# Altium schematic sheet Center Console - Raspberry Pi Interface.SchDoc("Center Console - Raspberry Pi Interface")
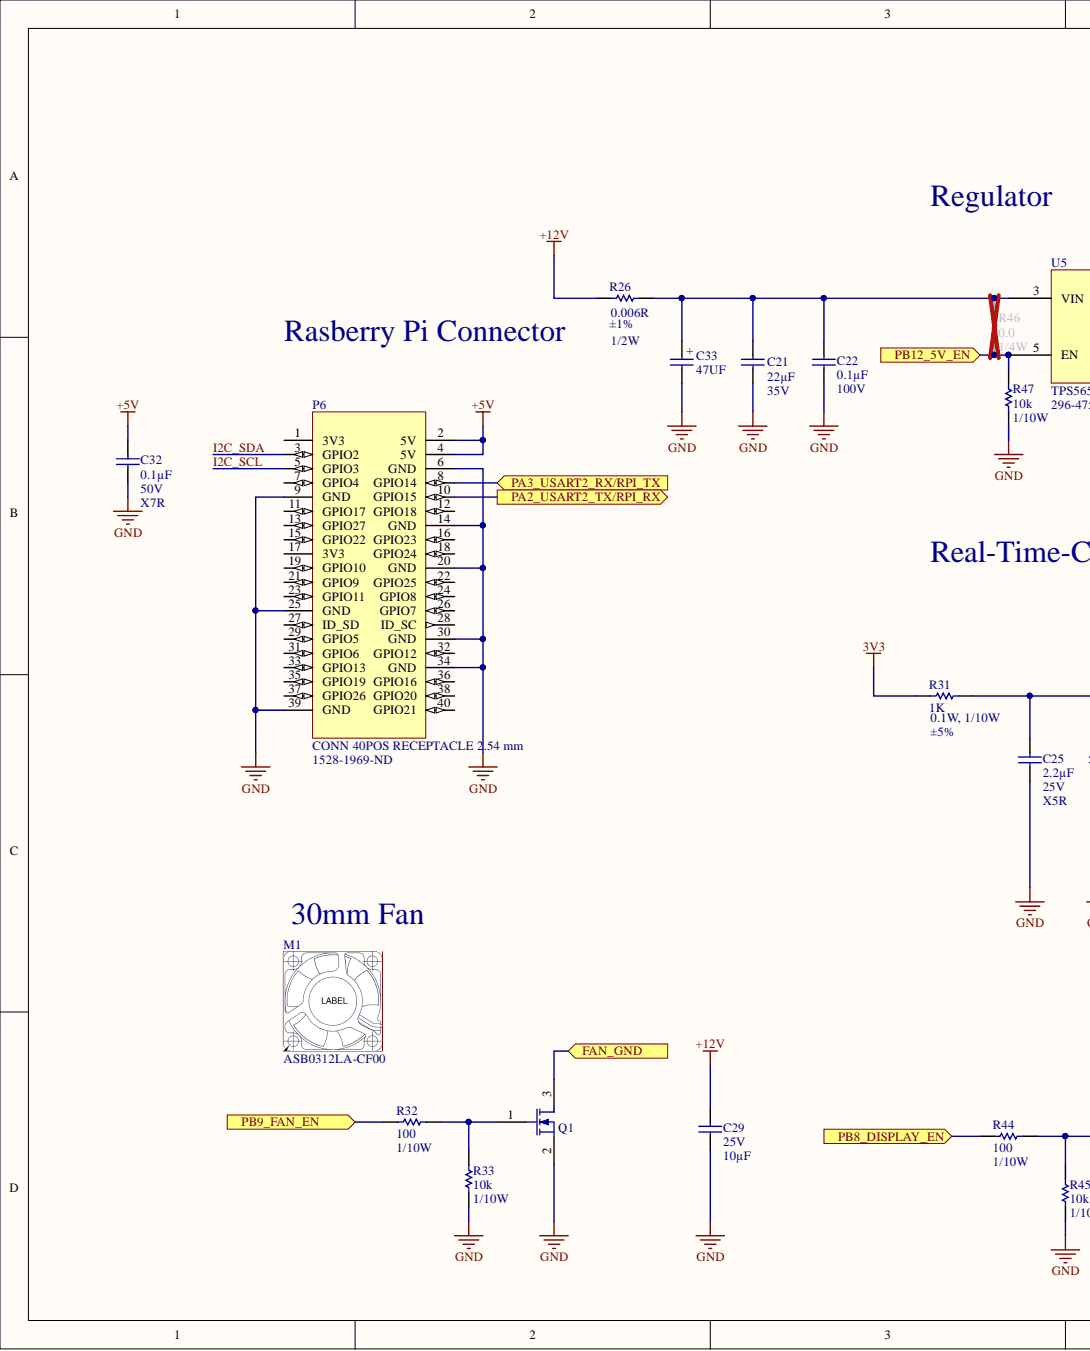
[diagram p0: Center Console - Raspberry Pi Interface.SchDoc, left]
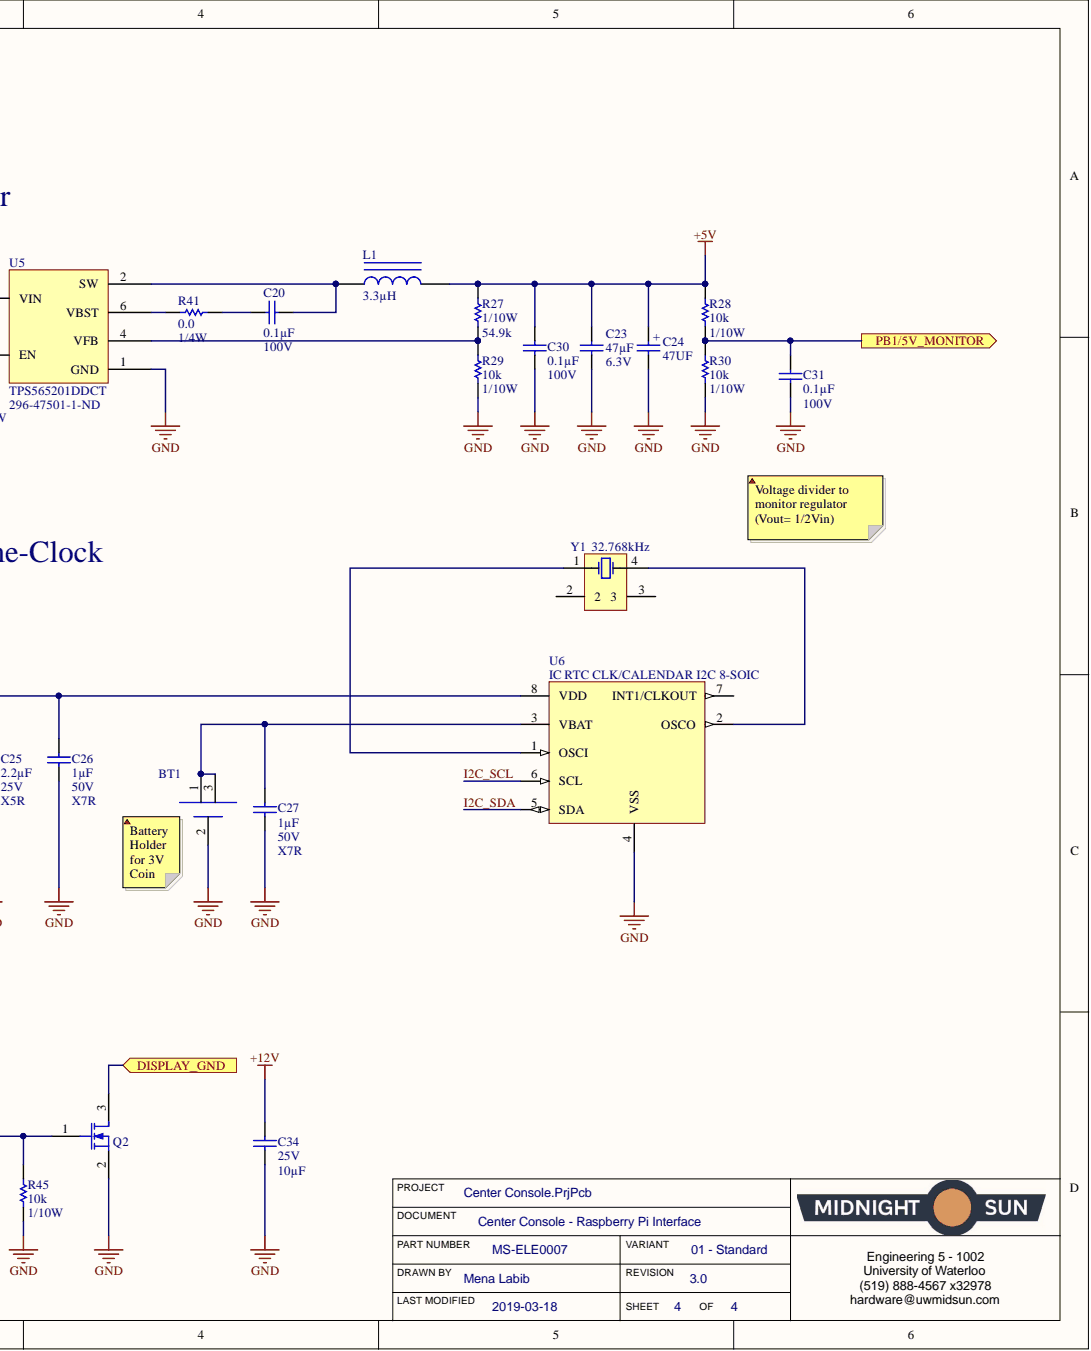
[diagram p1: Center Console - Raspberry Pi Interface.SchDoc, right]

## Parts
BT1  HOLDER BATTERY COIN 12MM DIA  [HOLDER COIN CELL 1220 3V]  (value not drawn)
C20  Voltage: 100V  Capacitance: 0.1µF  [CAP, 0805]  (hidden comment: CAP CER 0.1UF 100V 10% X7R 0805)
C21  Voltage Rating (DC): 35V  [CAP, 0805]  (hidden comment: CAP CER 22UF 35V X5R 0805)
C22  Voltage: 100V  Capacitance: 0.1µF  [CAP, 0805]  (hidden comment: CAP CER 0.1UF 100V 10% X7R 0805)
C23  Voltage Rating (DC): 6.3V  [1210]  (hidden comment: CAP CER 47UF 6.3V X7R 1210)
C24  47UF  [CAP ALUM 6.3MM RADIAL SMD]
C25  Voltage: 25V  Temperature Coefficient: X5R  Capacitance: 2.2µF  [CAP, 0603]  (hidden comment: CAP CER 2.2UF 25V 10% X5R 0603)
C26  Voltage: 50V  Temperature Coefficient: X7R  Capacitance: 1µF  [CAP, 0603]  (hidden comment: CAP CER 1UF 50V 10% X7R 0603)
C27  Voltage: 50V  Temperature Coefficient: X7R  Capacitance: 1µF  [CAP, 0603]  (hidden comment: CAP CER 1UF 50V 10% X7R 0603)
C29  Voltage - Rated: 25V  Capacitance: 10µF  [CAP, 0805]  (hidden comment: CAP CER 10uF 25V 10% X5R 0805)
C30  Voltage: 100V  Capacitance: 0.1µF  [CAP, 0805]  (hidden comment: CAP CER 0.1UF 100V 10% X7R 0805)
C31  Voltage: 100V  Capacitance: 0.1µF  [CAP, 0805]  (hidden comment: CAP CER 0.1UF 100V 10% X7R 0805)
C32  Voltage: 50V  Temperature Coefficient: X7R  Capacitance: 0.1µF  [CAP, 0603]  (hidden comment: CAP CER 0.1UF 50V 10% X7R 0603)
C33  47UF  [CAP ALUM 6.3MM RADIAL SMD]
C34  Voltage - Rated: 25V  Capacitance: 10µF  [CAP, 0805]  (hidden comment: CAP CER 10uF 25V 10% X5R 0805)
L1  Inductance: 3.3µH  [IND 3.3UH 5.2A 20 MOHM SMD VLP8040]  (hidden comment: IND 3.3uH 5.2A 20MOHM SMD)
M1  Manufacturer Part Number: ASB0312LA-CF00  [FAN AXIAL 30x10MM 12VDC]  (hidden comment: FAN AXIAL 30x10MM 12VDC)
P6  CONN 40POS RECEPTACLE 2.54 mm  Supplier Part Number 1: 1528-1969-ND  [CONN 40POS RECEPTACLE 2.54 MM]
Q1  MOSFET N-CH 30V 6.2A 0.9W SOT-23  [MOSFET N-CH 30V 6.2A 0.9W SOT-23]  (value not drawn)
Q2  MOSFET N-CH 30V 6.2A 0.9W SOT-23  [MOSFET N-CH 30V 6.2A 0.9W SOT-23]  (value not drawn)
R26  Tolerance: ±1%  Resistance (Ohms): 0.006R  [RES 1206]  (hidden comment: RES 0.006 OHM 1% 1/2W 1206)
R27  Resistance (Ohms): 54.9k  Power (Watts): 1/10W  [RES, 0603]  (hidden comment: RES 54.9K OHM 1% 1/10W 0603)
R28  Resistance: 10k  Power: 1/10W  [RES, 0603]  (hidden comment: RES 10K OHM 1% 1/10W 0603)
R29  Resistance: 10k  Power: 1/10W  [RES, 0603]  (hidden comment: RES 10K OHM 1% 1/10W 0603)
R30  Resistance: 10k  Power: 1/10W  [RES, 0603]  (hidden comment: RES 10K OHM 1% 1/10W 0603)
R31  1K  Tolerance: ±5%  Power (Watts): 0.1W, 1/10W  [RES, 0603]
R32  Power: 1/10W  [RES, 0603]  (hidden comment: RES 100 OHM 1% 1/10W 0603)
R33  Resistance: 10k  Power: 1/10W  [RES, 0603]  (hidden comment: RES 10K OHM 1% 1/10W 0603)
R41  Resistance: 0.0  Power (Watts): 1/4W  [RES, 0603]  (hidden comment: RES 0.0 OHM 1/4W 0603)
R44  Power: 1/10W  [RES, 0603]  (hidden comment: RES 100 OHM 1% 1/10W 0603)
R45  Resistance: 10k  Power: 1/10W  [RES, 0603]  (hidden comment: RES 10K OHM 1% 1/10W 0603)
R46  Resistance: 0.0  Power (Watts): 1/4W  [RES, 0603]  (hidden comment: RES 0.0 OHM 1/4W 0603)
R47  Resistance: 10k  Power: 1/10W  [RES, 0603]  (hidden comment: RES 10K OHM 1% 1/10W 0603)
U5  Supplier Part Number 1: 296-47501-1-ND  Manufacturer Part Number: TPS565201DDCT  [IC REG BUCK 4.5V TO 17V, 5A]  (hidden comment: IC REG BUCK 4.5V TO 17V, 5A, SYNCHRONOUS STE)
U6  IC RTC CLK/CALENDAR I2C 8-SOIC  Interface: I2C  [SOIC8]
Y1  Frequency: 32.768kHz  [4-SOJ]  (hidden comment: CRYSTAL 32.7680KHZ 12.5PF SMD)

## Ports
DISPLAY_GND, FAN_GND, PA2_USART2_TX/RPI_RX, PA3_USART2_RX/RPI_TX, PB1/5V_MONITOR, PB8_DISPLAY_EN, PB9_FAN_EN, PB12_5V_EN

## Nets
3V3: R31.1
+5V: C23.1 C24.1 C30.1 C32.1 L1.2 P6.2(5V) P6.4(5V) R27.1 R28.1
+12V: C29.1 C34.1 R26.1
GND: BT1.2 C21.2 C22.2 C23.2 C24.2 C25.2 C26.2 C27.2 C29.2 C30.2 C31.2 C32.2 C33.2 C34.2 P6.6(GND) P6.9(GND) P6.14(GND) P6.20(GND) P6.25(GND) P6.30(GND) P6.34(GND) P6.39(GND) Q1.2 Q2.2 R29.2 R30.2 R33.2 R45.2 R47.2 U5.1(GND) U6.4(VSS)
DISPLAY_GND: Q2.3 ; port DISPLAY_GND
FAN_GND: Q1.3 ; port FAN_GND
I2C_SCL_4: P6.5(GPIO3) U6.6(SCL)  [also labelled I2C_SCL]
I2C_SDA_4: P6.3(GPIO2) U6.5(SDA)  [also labelled I2C_SDA]
PA2_USART2_TX/RPI_RX: P6.10(GPIO15) ; port PA2_USART2_TX/RPI_RX
PA3_USART2_RX/RPI_TX: P6.8(GPIO14) ; port PA3_USART2_RX/RPI_TX
PB1/5V_MONITOR: C31.1 R28.2 R30.1 ; port PB1/5V_MONITOR
PB8_DISPLAY_EN: R44.1 ; port PB8_DISPLAY_EN
PB9_FAN_EN: R32.1 ; port PB9_FAN_EN
PB12_5V_EN: R46.2 R47.1 U5.5(EN) ; port PB12_5V_EN
NetBT1_1: BT1.1 BT1.3 C27.1 U6.3(VBAT)
NetC20_1: C20.1 R41.2
NetC20_2: C20.2 L1.1 U5.2(SW)
NetC21_1: C21.1 C22.1 C33.1 R26.2 R46.1 U5.3(VIN)
NetC25_1: C25.1 C26.1 R31.2 U6.8(VDD)
NetP6_1: P6.1(3V3)
NetP6_7: P6.7(GPIO4)
NetP6_11: P6.11(GPIO17)
NetP6_12: P6.12(GPIO18)
NetP6_13: P6.13(GPIO27)
NetP6_15: P6.15(GPIO22)
NetP6_16: P6.16(GPIO23)
NetP6_17: P6.17(3V3)
NetP6_18: P6.18(GPIO24)
NetP6_19: P6.19(GPIO10)
NetP6_21: P6.21(GPIO9)
NetP6_22: P6.22(GPIO25)
NetP6_23: P6.23(GPIO11)
NetP6_24: P6.24(GPIO8)
NetP6_26: P6.26(GPIO7)
NetP6_27: P6.27(ID_SD)
NetP6_28: P6.28(ID_SC)
NetP6_29: P6.29(GPIO5)
NetP6_31: P6.31(GPIO6)
NetP6_32: P6.32(GPIO12)
NetP6_33: P6.33(GPIO13)
NetP6_35: P6.35(GPIO19)
NetP6_36: P6.36(GPIO16)
NetP6_37: P6.37(GPIO26)
NetP6_38: P6.38(GPIO20)
NetP6_40: P6.40(GPIO21)
NetQ1_1: Q1.1 R32.2 R33.1
NetQ2_1: Q2.1 R44.2 R45.1
NetR27_2: R27.2 R29.1 U5.4(VFB)
NetR41_1: R41.1 U5.6(VBST)
NetU6_1: U6.1(OSCI) Y1.1
NetU6_2: U6.2(OSCO) Y1.4
NetU6_7: U6.7(INT1/CLKOUT)
NetY1_2: Y1.2
NetY1_3: Y1.3

## Library data of the parts
BT1 = HOLDER BATTERY COIN 12MM DIA: Coin cell holder smd; Manufacturer Part Number: 3000; Manufacturer: Keystone Electronics; Number of Cells: 1; Body Material: Bronze; Termination: SMD/SMT; Supplier Part Number 1: 36-3000-ND; Supplier 1: Digi-Key; Stock 1: 17093; REACH SVHC: No SVHC; Pricing 1: 1=0.58, 10=0.545, 50=0.4356, 100=0.4175, 250=0.38116, 500=0.363, 1000=0.30495, 2500=0.27225, 5000=0.26318 (USD); Packaging: Bulk; Package Quantity: 100; Number of Batteries: 1; Mount: Surface Mount,PCB; Material: Bronze; Lead Free: Lead Free; Height: 3.17mm; Diameter: 12mm; Contact Plating: Nickel; Contact Material: Bronze,Nickel; ComponentLink4URL: https://datasheet.ciiva.com/1391/1718262-1391630.pdf; ComponentLink4Description: https://datasheet.ciiva.com/1391/1718262-1391630.pdf; ComponentLink3URL: https://datasheet.ciiva.com/20221/18-3570e-20221596.pdf; ComponentLink3Description: https://datasheet.ciiva.com/20221/18-3570e-20221596.pdf; ComponentLink2URL: https://datasheet.ciiva.com/11714/0900766b8002ef78-11714721.pdf; ComponentLink2Description: https://datasheet.ciiva.com/11714/0900766b8002ef78-11714721.pdf; ComponentLink1URL: https://datasheet.ciiva.com/3/3000-3953.pdf; ComponentLink1Description: https://datasheet.ciiva.com/3/3000-3953.pdf; Component Kind: Standard; Library Name: Schematic Diagrams.SchLib
C20 C22 C30 C31 = CAP CER 0.1UF 100V 10% X7R 0805: 0.1µF ±10% 100V Ceramic Capacitor X7R 0805 (2012 Metric); Manufacturer Part Number 1: GCM21BR72A104KA37L; Manufacturer 1: Murata Electronics North America; Tolerance: ±10%; Thickness (Max): 0.055" (1.40mm); Temperature Coefficient: X7R; Supplier Part Number 1: 490-4789-1-ND; Supplier 1: Digi-Key; Size / Dimension: 0.079" L x 0.049" W (2.00mm x 1.25mm); Ratings: AEC-Q200; Part Status: Active; Packaging: Cut Tape (CT); Package / Case: 0805 (2012 Metric); Operating Temperature: -55°C ~ 125°C; Mounting Type: Surface Mount, MLCC; Lead Style: -; Lead Spacing: -; Height - Seated (Max): -; ComponentLink1URL: http://www.murata.com/~/media/webrenewal/support/library/catalog/products/capacitor/mlcc/c03e.ashx; ComponentLink1Description: http://www.murata.com/~/media/webrenewal/support/library/catalog/products/capacitor/mlcc/c03e.ashx; Component Kind: Standard; Library Name: Schematic Diagrams.SchLib
C21 = CAP CER 22UF 35V X5R 0805: 22µF ±20% 35V Ceramic Capacitor X5R 0805 (2012 Metric); Manufacturer Part Number: C2012X5R1V226M125AC; Manufacturer: TDK; Width: 0.049inch; Weight: 0.000194oz; Voltage Rating: 35V; Tolerance: 20%; Thickness: 0.057inch; Termination: SMD/SMT; Supplier Part Number 1: 445-14428-1-ND; Supplier 1: Digi-Key; Stock 1: 30; RoHS: Yes; REACH SVHC: No SVHC; Pricing 1: 1 = 1.29000, 10 = 0.98100, 100 = 0.70190, 500 = 0.54338, 1000 = 0.46791 (USD); Packaging: Tape and Reel; Package Quantity: 2000; Mount: Surface Mount; Min Operating Temperature: -55°C; Max Operating Temperature: 85°C; Length: 0.079inch; Lead Free: Lead Free; Height: 1.25mm; Dielectric Material: Ceramic; Dielectric: X5R; ComponentLink1URL: https://product.tdk.com/info/en/catalog/spec/mlccspec_commercial_general_midvoltage_en.pdf; ComponentLink1Description: https://product.tdk.com/info/en/catalog/spec/mlccspec_commercial_general_midvoltage_en.pdf; Component Kind: Standard; Case Code (Metric): 2012; Case Code (Imperial): 0805; Case/Package: 0805; Capacitance: 22uF; Library Name: Schematic Diagrams.SchLib
C23 = CAP CER 47UF 6.3V X7R 1210: 47µF ±10% 6.3V Ceramic Capacitor X7R 1210 (3225 Metric); Manufacturer Part Number: GCJ32ER70J476KE01L; Manufacturer: Murata; Width: 0.098inch; Weight: 0.00388oz; Voltage Rating: 6.3V; Tolerance: 10%; Thickness: 0.11inch; Termination: SMD/SMT; Supplier Part Number 1: 490-10559-1-ND; Supplier 1: Digi-Key; Stock 1: 191399; RoHS: Yes; Ratings: AEC-Q200; Pricing 1: 1 = 1.53000, 10 = 1.21200, 100 = 0.90890, 500 = 0.68670 (USD); Packaging: Tape and Reel; Package Quantity: 1000; Mount: Surface Mount; Min Operating Temperature: -55°C; Max Operating Temperature: 125°C; Length: 0.126inch; Lead Free: Lead Free; Height: 2.5mm; Features: Soft Termination; Dielectric: X7R; Component Kind: Standard; Case Code (Metric): 3225; Case Code (Imperial): 1210; Case/Package: 1210; Capacitance: 47uF; Library Name: Schematic Diagrams.SchLib
C24 C33 = CAP ALUM 47UF 20% 35V SMD: 47µF 35V Aluminum Electrolytic Capacitors Radial, Can - SMD 1000 Hrs @ 85°C; Manufacturer Part Number: EEE-1VA470WP; Manufacturer: Panasonic; Tolerance: 20%; Ripple Current (AC): 70mA; Life (Hours): 1000Hour; Voltage Rating (DC): 35V; Voltage Rating: 35V; Termination: SMD/SMT; Supplier Part Number 1: PCE3961CT-ND; Supplier 1: Digi-Key; Stock 1: 2820; Ripple Current: 70mA; REACH SVHC: Unknown; Radiation Hardening: No; Pricing 1: 1=0.38, 10=0.263, 100=0.1579, 500=0.11846 (USD); Packaging: Tape and Reel; Package Quantity: 1; Mount: Surface Mount,PCB; Min Operating Temperature: -40°C; Max Operating Temperature: 85°C; Length: 5.5mm; Lead Free: Lead Free; Height - Seated (Max): 0.213inch; Height: 5.4mm; Diameter: 6.3mm; Contact Plating: Tin; ComponentLink6URL: https://datasheet.ciiva.com/30206/11aba0000ce2-30206253.pdf; ComponentLink6Description: https://datasheet.ciiva.com/30206/11aba0000ce2-30206253.pdf; ComponentLink5URL: https://datasheet.ciiva.com/16507/aba0000ce2-16507965.pdf; ComponentLink5Description: https://datasheet.ciiva.com/16507/aba0000ce2-16507965.pdf; ComponentLink4URL: https://datasheet.ciiva.com/15165/getdatasheetpartid-86239-15165868.pdf; ComponentLink4Description: https://datasheet.ciiva.com/15165/getdatasheetpartid-86239-15165868.pdf; ComponentLink3URL: https://datasheet.ciiva.com/14598/70255710-14598937.pdf; ComponentLink3Description: https://datasheet.ciiva.com/14598/70255710-14598937.pdf; ComponentLink2URL: https://datasheet.ciiva.com/2983/aba0000ce2-2983927.pdf; ComponentLink2Description: https://datasheet.ciiva.com/2983/aba0000ce2-2983927.pdf; ComponentLink1URL: https://datasheet.ciiva.com/2983/aba0000ce2-2983926.pdf; ComponentLink1Description: https://datasheet.ciiva.com/2983/aba0000ce2-2983926.pdf; Component Kind: Standard; Case/Package: Radial; Capacitance: 47uF
  C24: Library Name: Schematic Diagrams.SchLib
C25 = CAP CER 2.2UF 25V 10% X5R 0603: 2.2µF ±10% 25V Ceramic Capacitor X5R 0603 (1608 Metric); Manufacturer Part Number 1: GRM188R61E225KA12D; Manufacturer 1: Murata Electronics North America; Tolerance: ±10%; Thickness (Max): 0.035" (0.90mm); Supplier Part Number 1: 490-10731-1-ND; Supplier 1: Digi-Key; Size / Dimension: 0.063" L x 0.031" W (1.60mm x 0.80mm); Ratings: -; Part Status: Active; Packaging: Cut Tape (CT); Package / Case: 0603 (1608 Metric); Operating Temperature: -55°C ~ 85°C; Mounting Type: Surface Mount, MLCC; Lead Style: -; Lead Spacing: -; Height - Seated (Max): -; ComponentLink1URL: http://www.murata.com/~/media/webrenewal/support/library/catalog/products/capacitor/mlcc/c02e.ashx; ComponentLink1Description: http://www.murata.com/~/media/webrenewal/support/library/catalog/products/capacitor/mlcc/c02e.ashx; Component Kind: Standard; Library Name: Schematic Diagrams.SchLib
C26 C27 = CAP CER 1UF 50V 10% X7R 0603: 1µF ±10% 50V Ceramic Capacitor X7R 0603 (1608 Metric); Manufacturer Part Number 1: UMK107AB7105KA-T; Manufacturer 1: Taiyo Yuden; Tolerance: ±10%; Thickness (Max): 0.037" (0.95mm); Supplier Part Number 1: 587-3247-1-ND; Supplier 1: Digi-Key; Size / Dimension: 0.063" L x 0.031" W (1.60mm x 0.80mm); Ratings: -; Part Status: Active; Packaging: Cut Tape (CT); Package / Case: 0603 (1608 Metric); Operating Temperature: -55°C ~ 125°C; Mounting Type: Surface Mount, MLCC; Lead Style: -; Lead Spacing: -; Height - Seated (Max): -; ComponentLink1URL: http://www.yuden.co.jp/productdata/catalog/en/mlcc_all_e.pdf; ComponentLink1Description: http://www.yuden.co.jp/productdata/catalog/en/mlcc_all_e.pdf; Component Kind: Standard; Library Name: Schematic Diagrams.SchLib
C29 C34 = CAP CER 10uF 25V 10% X5R 0805: 10µF ±10% 25V Ceramic Capacitor X5R 0805 (2012 Metric); Manufacturer Part Number: GRM21BR61E106KA73L; Manufacturer: Murata Electronics North America; Tolerance: ±10%; Thickness (Max): 0.053" (1.35mm); Temperature Coefficient: X5R; Supplier Part Number 1: 490-5523-1-ND; Supplier 1: Digi-Key; Stock 1: 1695157; Size / Dimension: 0.079" L x 0.049" W (2.00mm x 1.25mm); RoHS: RoHS Compliant; Ratings: -; Pricing 1: 1=0.3, 10=0.214, 100=0.1008, 500=0.07068, 1000=0.05851 (CAD); Part Status: Active; Packaging: Cut Tape (CT); Package / Case: 0805 (2012 Metric); Operating Temperature: -55°C ~ 85°C; Mounting Type: Surface Mount, MLCC; Lead Style: -; Lead Spacing: -; Height - Seated (Max): -; Features: -; ComponentLink1URL: http://search.murata.co.jp/Ceramy/image/img/A01X/G101/ENG/GRM21BR61E106KA73-01.pdf; ComponentLink1Description: http://search.murata.co.jp/Ceramy/image/img/A01X/G101/ENG/GRM21BR61E106KA73-01.pdf; Component Kind: Standard; Category: Capacitors; Applications: General Purpose
C32 = CAP CER 0.1UF 50V 10% X7R 0603: 0.10µF ±10% 50V Ceramic Capacitor X7R 0603 (1608 Metric); Manufacturer Part Number 1: 06035C104KAT2A; Manufacturer 1: AVX Corporation; Tolerance: ±10%; Thickness (Max): 0.035" (0.90mm); Supplier Part Number 1: 478-5052-1-ND; Supplier 1: Digi-Key; Size / Dimension: 0.063" L x 0.032" W (1.60mm x 0.81mm); Ratings: -; Part Status: Active; Packaging: Cut Tape (CT); Package / Case: 0603 (1608 Metric); Operating Temperature: -55°C ~ 125°C; Mounting Type: Surface Mount, MLCC; Lead Style: -; Lead Spacing: -; Height - Seated (Max): -; ComponentLink1URL: http://datasheets.avx.com/X7RDielectric.pdf; ComponentLink1Description: http://datasheets.avx.com/X7RDielectric.pdf; Component Kind: Standard; Library Name: Schematic Diagrams.SchLib
L1 = IND 3.3uH 5.2A 20MOHM SMD: 3.3µH Shielded Wirewound Inductor 5.2A 20 mOhm Max Nonstandard; Manufacturer Part Number: VLS201612CX-3R3M; Manufacturer: TDK Corporation; Tolerance: ±20%; Test Frequency: 100kHz; Material - Core: Ferrite; Inductance Tolerance: 30%; Frequency - Test: 1MHz; DC Resistance (DCR): 170 mOhm Max; Current Rating: 1.37A; Current - Saturation: 1.04A; Width: 8mm; Type: Wirewound; Termination: SMD/SMT; Supplier Part Number 1: 445-6581-1-ND; Supplier Device Package: 2016; Supplier 1: Digi-Key; Stock 1: 8114; Size / Dimension: 0.079" L x 0.063" W (2.00mm x 1.60mm); Shielding: Shielded; Saturation Current: 5.2A; RoHS: RoHS Compliant; RMS Current (Irms): 5.2A; REACH SVHC: No SVHC; Q @ Freq: -; Pricing 1: 1 = 0.60000, 10 = 0.52700, 100 = 0.37660, 500 = 0.31636 (USD); Packaging: Cut Tape (CT); Package Quantity: 1; Package / Case: Nonstandard; Operating Temperature: -40°C ~ 105°C; Mounting Type: Surface Mount; Mount: Surface Mount; Min Operating Temperature: -40°C; Military Standard: Not; Max Operating Temperature: 105°C; Max DC Current: 5.2A; Length: 0.315inch; Lead Free: Lead Free; Height - Seated (Max): 0.047" (1.20mm); Height: 4mm; Frequency - Self Resonant: -; Depth: 8.7mm; Core Material: Ferrite; Composition: Wirewound; ComponentLink2URL: http://www.mouser.com/ds/2/400/nductor_commercial_power_vlp8040_en-952627.pdf; ComponentLink2Description: http://www.mouser.com/ds/2/400/nductor_commercial_power_vlp8040_en-952627.pdf; ComponentLink1URL: http://product.tdk.com/en/catalog/datasheets/inductor_commercial_power_vls-cx_en.pdf; ComponentLink1Description: http://product.tdk.com/en/catalog/datasheets/inductor_commercial_power_vls-cx_en.pdf; Component Kind: Standard; Category: Inductors, Coils, Chokes; Case/Package: Nonstandard; Library Name: Schematic Diagrams.SchLib
M1 = FAN AXIAL 30x10MM 12VDC: FAN AXIAL 30X10MM 12VDC WIRE; Manufacturer: Delta Design; RPM: 8000RPM; Noise: 21dBA; Max Power Dissipation: 600mW; Current Rating: 50mA; Bearing Type: Sleeve; Airflow: 3.2CFM; Width: 10mm; Weight: 0.016lb; Termination: 3 Wire Leads; Supplier Part Number 1: 603-1728-ND; Supplier 1: Digi-Key; Stock 1: 2468; RoHS: Yes; Package Quantity: 1440; ComponentLink1URL: http://www.delta-fan.com/Download/Spec/ASB0312LA-CF00.pdf; ComponentLink1Description: http://www.delta-fan.com/Download/Spec/ASB0312LA-CF00.pdf; Component Kind: Standard; Case/Package: Axial
P6 = CONN 40POS RECEPTACLE 2.54 mm: GPIO HEADER FOR RASPBERRYPI A+/B; Manufacturer Part Number: 1992; Manufacturer: Adafruit Industries; Supplier 1: Digi-Key; Stock 1: 175; Pricing 1: 1=2.95 (USD); ComponentLink1URL: https://www.digikey.ca/product-detail/en/adafruit-industries-llc/1992/1528-1969-ND/6827092; ComponentLink1Description: gpio header raspberry pi; Component Kind: Standard; Library Name: Schematic Diagrams.SchLib
Q1 Q2 = MOSFET N-CH 30V 6.2A 0.9W SOT-23: N-Channel 30V 6.2A (Ta) 900mW (Ta) Surface Mount SOT-23; Manufacturer Part Number: DMN3023L-7; Manufacturer: Diodes; Rds On Max: 25mR; Input Capacitance: 873pF; Supplier Part Number 1: DMN3023L-7DICT-ND; Supplier 1: Digi-Key; Stock 1: 38246; Pricing 1: 1=0.47, 10=0.368, 100=0.2529, 500=0.1734, 1000=0.1301 (USD); Packaging: Tape and Reel; Mount: Surface Mount; Min Operating Temperature: -55°C; Max Power Dissipation: 900mW; Max Operating Temperature: 150°C; Drain to Source Voltage (Vdss): 30V; Continuous Drain Current (ID): 6.2A; ComponentLink2URL: http://www.mouser.com/ds/2/115/DMN3023L-959533.pdf; ComponentLink2Description: http://www.mouser.com/ds/2/115/DMN3023L-959533.pdf; ComponentLink1URL: https://www.diodes.com/assets/Datasheets/DMN3023L.pdf; ComponentLink1Description: https://www.diodes.com/assets/Datasheets/DMN3023L.pdf; Component Kind: Standard; Case/Package: TO-236-3
R26 = RES 0.006 OHM 1% 1/2W 1206: 0.006 Ohm ±1% 0.5W, 1/2W Chip Resistor 1206 (3216 Metric) Automotive AEC-Q200, Current Sense, Moisture Resistant Metal Element; Manufacturer Part Number: ERJ-MP2KF6M0U; Manufacturer: Panasonic Electronic Components; Temperature Coefficient: ±75ppm/°C; Supplier Part Number 1: P19333CT-ND; Supplier Device Package: 1206; Supplier 1: Digi-Key; Size / Dimension: 0.125" L x 0.063" W (3.18mm x 1.60mm); RoHS: RoHS Compliant; Power (Watts): 1/2W; Part Status: Active; Packaging: Cut Tape (CT); Package / Case: 1206 (3216 Metric); Operating Temperature: -65°C ~ 170°C; Height - Seated (Max): 0.035" (0.89mm); Features: Automotive AEC-Q200, Current Sense, Moisture Resistant; Failure Rate: -; Composition: Metal Element; ComponentLink1URL: http://industrial.panasonic.com/cdbs/www-data/pdf/RDN0000/AOA0000C263.pdf; ComponentLink1Description: http://industrial.panasonic.com/cdbs/www-data/pdf/RDN0000/AOA0000C263.pdf; Component Kind: Standard; Category: Resistors; Library Name: Schematic Diagrams.SchLib
R27 = RES 54.9K OHM 1% 1/10W 0603: 54.9k Ohm ±1% 0.1W, 1/10W Chip Resistor 0603 (1608 Metric) Automotive AEC-Q200 Thick Film; Manufacturer Part Number: ERJ-3EKF5492V; Manufacturer: Panasonic Electronic Components; Temperature Coefficient: ±100ppm/°C; Tolerance: ±1%; Supplier Part Number 1: P54.9KHCT-ND; Supplier Device Package: 0603; Supplier 1: Digi-Key; Stock 1: 74038; Size / Dimension: 0.063" L x 0.031" W (1.60mm x 0.80mm); RoHS: RoHS Compliant; Pricing 1: 1=0.16, 10=0.031, 100=0.0127, 1000=0.00567, 2500=0.00492 (CAD); Part Status: Active; Packaging: Cut Tape (CT); Package / Case: 0603 (1608 Metric); Operating Temperature: -55°C ~ 155°C; Height - Seated (Max): 0.022" (0.55mm); Features: Automotive AEC-Q200; Failure Rate: -; Composition: Thick Film; ComponentLink1URL: https://industrial.panasonic.com/cdbs/www-data/pdf/RDA0000/AOA0000C298.pdf; ComponentLink1Description: https://industrial.panasonic.com/cdbs/www-data/pdf/RDA0000/AOA0000C298.pdf; Component Kind: Standard; Category: Resistors; Library Name: Schematic Diagrams.SchLib
R28-R30 R33 R45 R47 = RES 10K OHM 1% 1/10W 0603: RES SMD 10K OHM 1% 1/10W 0603; Manufacturer Part Number 1: RC0603FR-0710KL; Manufacturer 1: Yageo; Temperature Coefficient: ±100ppm/°C; Tolerance: ±1%; Supplier Part Number 1: 311-10.0KHRCT-ND; Supplier Device Package: 0603; Supplier 1: Digi-Key; Size / Dimension: 0.063" L x 0.031" W (1.60mm x 0.80mm); Part Status: Active; Packaging: Cut Tape (CT); Package / Case: 0603 (1608 Metric); Operating Temperature: -55°C ~ 155°C; Height - Seated (Max): 0.022" (0.55mm); Failure Rate: -; Composition: Thick Film; ComponentLink1URL: http://www.yageo.com/documents/recent/PYu-RC_Group_51_RoHS_L_7.pdf; ComponentLink1Description: http://www.yageo.com/documents/recent/PYu-RC_Group_51_RoHS_L_7.pdf; Component Kind: Standard
  R28-R30 R47: Library Name: Schematic Diagrams.SchLib
R31 = RES 1K OHM 5% 1/10W 0603: 1k Ohm ±5% 0.1W, 1/10W Chip Resistor 0603 (1608 Metric); Manufacturer Part Number: RC0603JR-071KL; Manufacturer: Yageo; Temperature Coefficient: ±100ppm/°C; Supplier Part Number 1: 311-1.0KGRCT-ND; Supplier Device Package: 0603; Supplier 1: Digi-Key; Stock 1: 4415240; Size / Dimension: 0.063" L x 0.031" W (1.60mm x 0.80mm); RoHS: RoHS Compliant; Resistance (Ohms): 1k; Pricing 1: 1=0.15, 10=0.017, 100=0.0071, 1000=0.0032, 2500=0.00278 (CAD); Part Status: Active; Packaging: Cut Tape (CT); Package / Case: 0603 (1608 Metric); Operating Temperature: -55°C ~ 155°C; Height - Seated (Max): 0.022" (0.55mm); Features: Moisture Resistant; Failure Rate: -; Composition: Thick Film; ComponentLink1URL: http://www.yageo.com/documents/recent/PYu-RC_Group_51_RoHS_L_7.pdf; ComponentLink1Description: http://www.yageo.com/documents/recent/PYu-RC_Group_51_RoHS_L_7.pdf; Component Kind: Standard; Category: Resistors; Library Name: Schematic Diagrams.SchLib
R32 R44 = RES 100 OHM 1% 1/10W 0603: 100 Ohm ±1% 0.1W, 1/10W Chip Resistor 0603 (1608 Metric) Moisture Resistant Thick Film; Manufacturer Part Number 1: RC0603FR-07100RL; Manufacturer 1: Yageo; Temperature Coefficient: ±100ppm/°C; Resistance: 100; Tolerance: ±1%; Supplier Part Number 1: 311-100HRCT-ND; Supplier Device Package: 0603; Supplier 1: Digi-Key; Size / Dimension: 0.063" L x 0.031" W (1.60mm x 0.80mm); Part Status: Active; Packaging: Cut Tape (CT); Package / Case: 0603 (1608 Metric); Operating Temperature: -55°C ~ 155°C; Height - Seated (Max): 0.022" (0.55mm); Failure Rate: -; Composition: Thick Film; ComponentLink1URL: http://www.yageo.com/documents/recent/PYu-RC_Group_51_RoHS_L_7.pdf; ComponentLink1Description: http://www.yageo.com/documents/recent/PYu-RC_Group_51_RoHS_L_7.pdf; Component Kind: Standard
R41 R46 = RES 0.0 OHM 1/4W 0603: RES SMD 0.0 OHM JUMPER 1/4W 0603; Manufacturer Part Number 1: CRCW06030000Z0EAHP; Manufacturer 1: Vishay Dale; Supplier Part Number 1: 541-0.0SBCT-ND; Supplier Device Package: 0603; Supplier 1: Digi-Key; Size / Dimension: 0.063" L x 0.033" W (1.60mm x 0.85mm); Part Status: Active; Packaging: Cut Tape (CT); Package / Case: 0603 (1608 Metric); Operating Temperature: -55°C ~ 155°C; Height - Seated (Max): 0.022" (0.55mm); Failure Rate: -; Composition: Thick Film; ComponentLink1URL: http://www.vishay.com/docs/20043/crcwhpe3.pdf; ComponentLink1Description: http://www.vishay.com/docs/20043/crcwhpe3.pdf; Component Kind: Standard
  R46: Library Name: Schematic Diagrams.SchLib
U5 = IC REG BUCK 4.5V TO 17V, 5A, SYNCHRONOUS STE: Buck Switching Regulator IC Positive Adjustable 0.6V 1 Output 5A SOT-23-6 Thin, TSOT-23-6; Manufacturer: Texas Instruments; Supplier 1: Digi-Key; Stock 1: 2226; Pricing 1: 1 = 2.46000, 10 = 2.21100, 100 = 1.77710 (USD); Min Operating Temperature: -40°C; Max Operating Temperature: 125°C; Component Kind: Standard; Library Name: Schematic Diagrams.SchLib
U6 = IC RTC CLK/CALENDAR I2C 8-SOIC: Real Time Clock (RTC) IC Clock/Calendar I²C, 2-Wire Serial 8-SOIC (0.154", 3.90mm Width); Manufacturer Part Number: PCF8523T/1,118; Manufacturer: NXP Semiconductors; Time Format: HH:MM:SS; Min Supply Voltage: 1.2V; Max Supply Voltage: 5.5V; Supplier Part Number 1: 568-5306-1-ND; Supplier 1: Digi-Key; Stock 1: 18252; REACH SVHC: No SVHC; Radiation Hardening: No; Pricing 1: 1=1.3, 10=1.16, 100=0.9045, 500=0.74718, 1000=0.58988 (USD); Packaging: Tape and Reel; Package Quantity: 2500; Number of Pins: 8; Mount: Surface Mount; Min Operating Temperature: -40°C; Max Operating Temperature: 85°C; Logic Function: Clock; Lead Free: Lead Free; Contact Plating: Gold; ComponentLink5URL: https://datasheet.ciiva.com/1238/pcf8523-1238782.pdf; ComponentLink5Description: https://datasheet.ciiva.com/1238/pcf8523-1238782.pdf; ComponentLink4URL: https://datasheet.ciiva.com/16286/pcf8523-16286477.pdf; ComponentLink4Description: https://datasheet.ciiva.com/16286/pcf8523-16286477.pdf; ComponentLink3URL: https://datasheet.ciiva.com/1238/pcf8523-1238781.pdf; ComponentLink3Description: https://datasheet.ciiva.com/1238/pcf8523-1238781.pdf; ComponentLink2URL: https://datasheet.ciiva.com/1238/pcf8523-1238780.pdf; ComponentLink2Description: https://datasheet.ciiva.com/1238/pcf8523-1238780.pdf; ComponentLink1URL: https://datasheet.ciiva.com/15152/getdatasheetpartid-445247-15152839.pdf; ComponentLink1Description: https://datasheet.ciiva.com/15152/getdatasheetpartid-445247-15152839.pdf; Component Kind: Standard; Case/Package: SO; Library Name: Schematic Diagrams.SchLib
Y1 = CRYSTAL 32.7680KHZ 12.5PF SMD: 32.768kHz ±20ppm Crystal 12.5pF 50 kOhms 4-SOJ, 5.50mm pitch; Manufacturer Part Number: ECS-.327-12.5-17X-TR; Manufacturer: ECS International; ESR (Equivalent Series Resistance): 50kR; Width: 0.15inch; Tolerance: 20ppm; Termination: SMD/SMT; Supplier Part Number 1: XC1195CT-ND; Supplier 1: Digi-Key; Stock 1: 67622; Pricing 1: 1=0.56, 10=0.468, 50=0.4208, 100=0.374, 500=0.3553, 1000=0.2992 (USD); Packaging: Tape and Reel; Package Quantity: 1; Mount: Surface Mount; Min Operating Temperature: -40°C; Max Operating Temperature: 85°C; Load Capacitance: 12.5pF; Length: 0.315inch; Lead Free: Lead Free; Height: 0.098inch; Frequency Tolerance: 20ppm; ComponentLink3URL: https://datasheet.ciiva.com/852/ecx-306x-852777.pdf; ComponentLink3Description: https://datasheet.ciiva.com/852/ecx-306x-852777.pdf; ComponentLink2URL: https://datasheet.ciiva.com/852/ecx-306x-852776.pdf; ComponentLink2Description: https://datasheet.ciiva.com/852/ecx-306x-852776.pdf; ComponentLink1URL: https://datasheet.ciiva.com/852/ecx-306x-852775.pdf; ComponentLink1Description: https://datasheet.ciiva.com/852/ecx-306x-852775.pdf; Component Kind: Standard; Library Name: Schematic Diagrams.SchLib
